# Altium schematic sheet 16gpio_misc.SchDoc
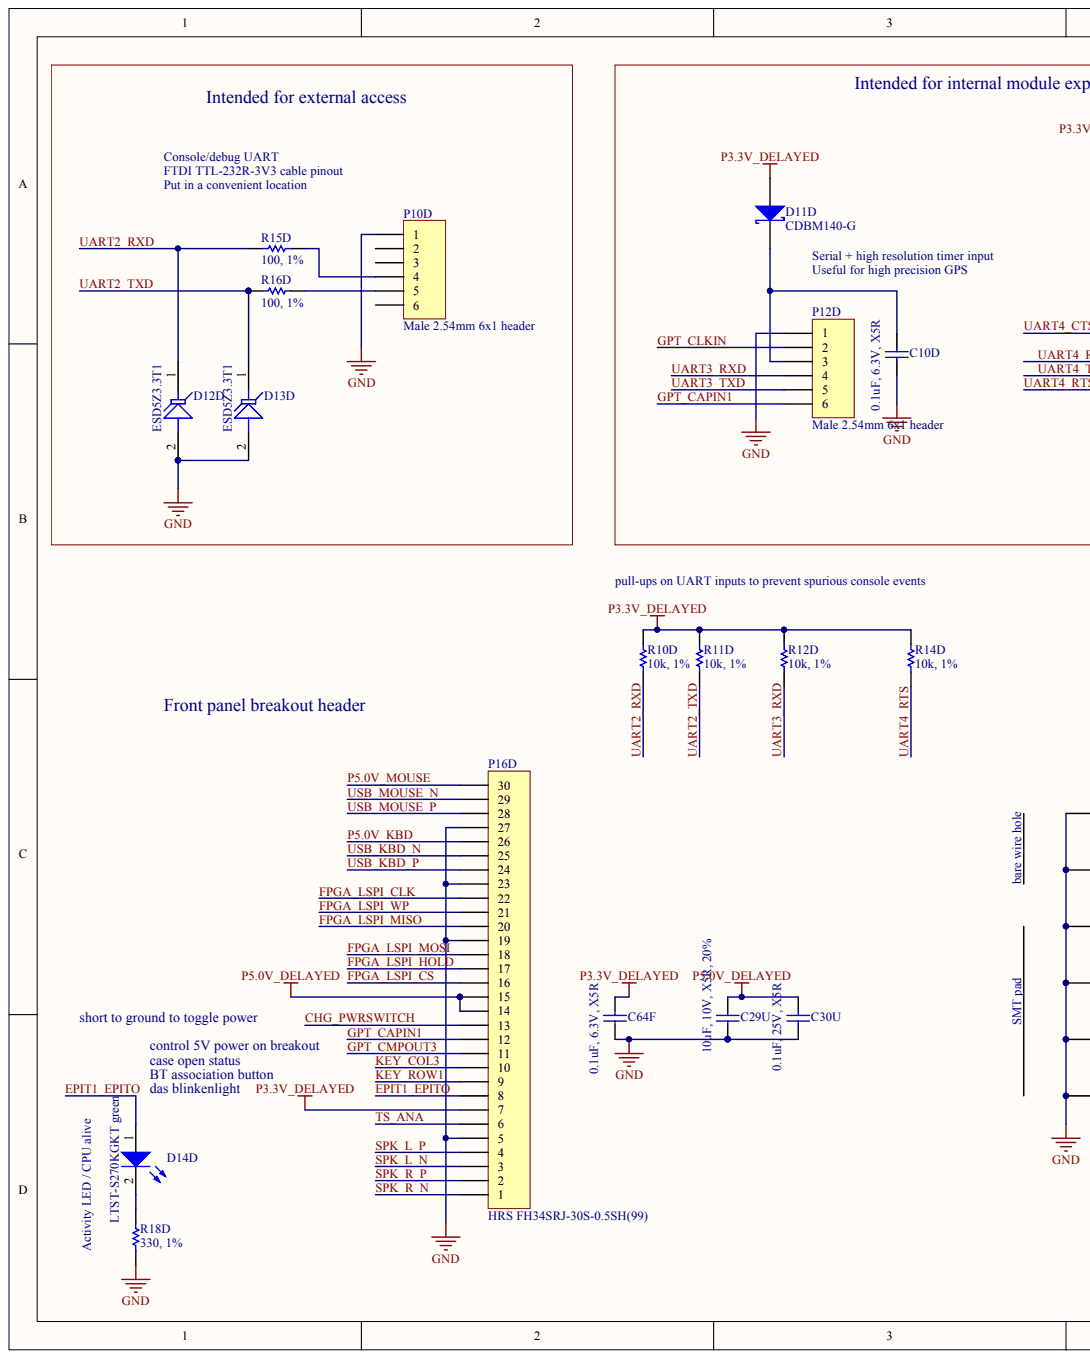
[diagram p0: 16gpio_misc.SchDoc, left]
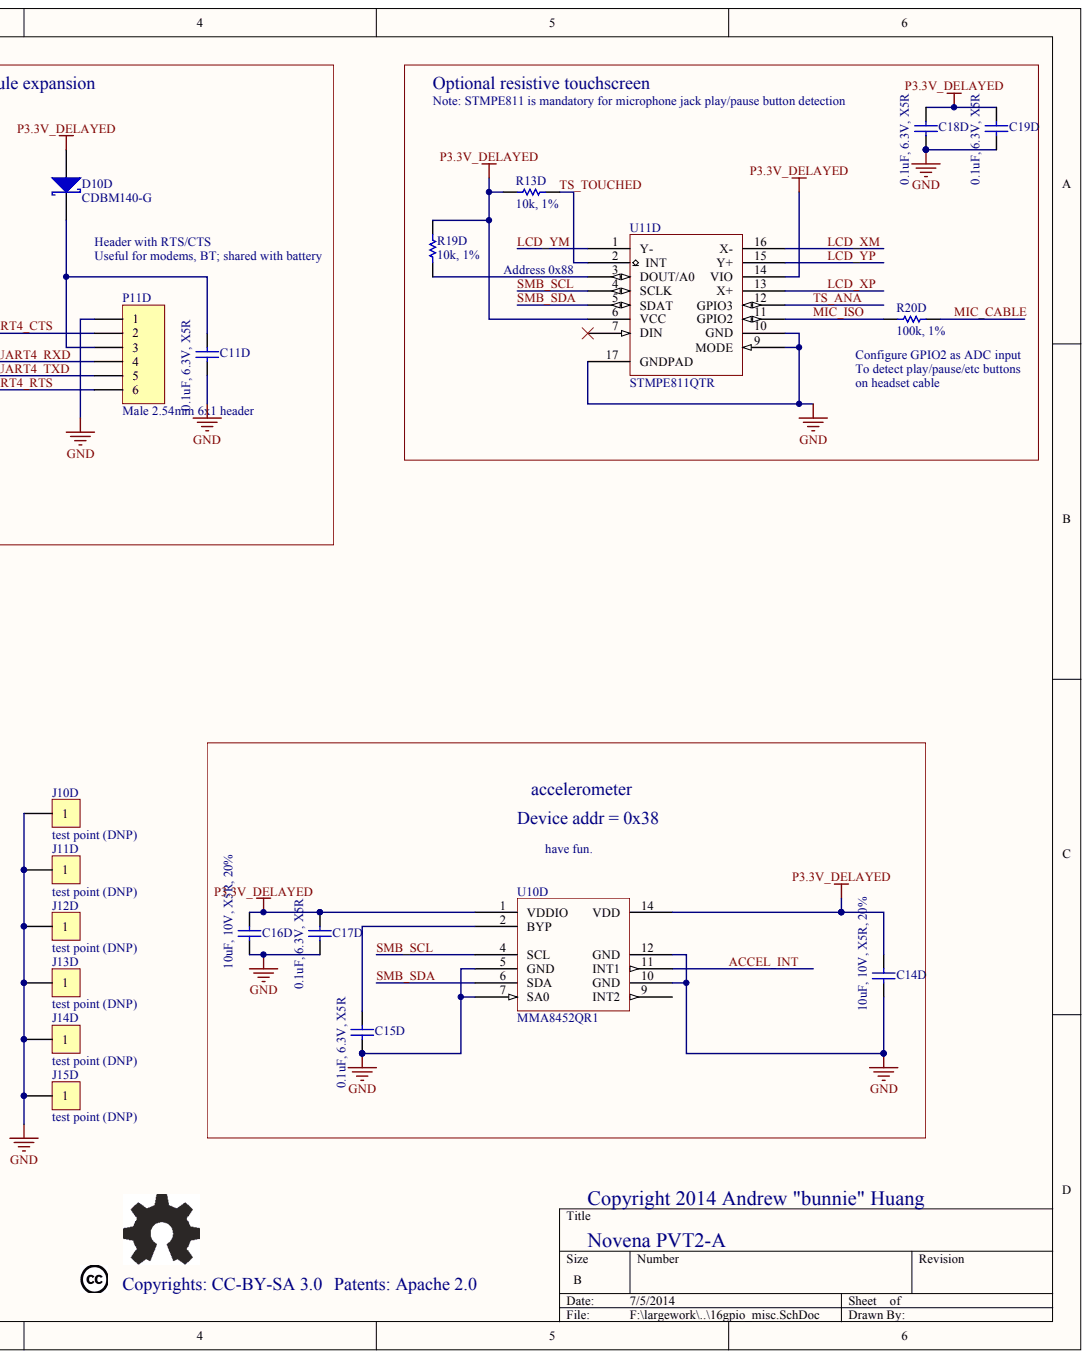
[diagram p1: 16gpio_misc.SchDoc, right]

## Parts
C10D  0.1uF, 6.3V, X5R
C11D  0.1uF, 6.3V, X5R
C14D  10uF, 10V, X5R, 20%
C15D  0.1uF, 6.3V, X5R
C16D  10uF, 10V, X5R, 20%
C17D  0.1uF, 6.3V, X5R
C18D  0.1uF, 6.3V, X5R
C19D  0.1uF, 6.3V, X5R
C29U  10uF, 10V, X5R, 20%
C30U  0.1uF, 25V, X5R
C64F  0.1uF, 6.3V, X5R
D10D  CDBM140-G
D11D  CDBM140-G
D12D  ESD5Z3.3T1
D13D  ESD5Z3.3T1
D14D  LTST-S270KGKT green
J10D  test point (DNP)
J11D  test point (DNP)
J12D  test point (DNP)
J13D  test point (DNP)
J14D  test point (DNP)
J15D  test point (DNP)
P10D  Male 2.54mm 6x1 header
P11D  Male 2.54mm 6x1 header
P12D  Male 2.54mm 6x1 header
P16D  HRS FH34SRJ-30S-0.5SH(99)
R10D  10k, 1%
R11D  10k, 1%
R12D  10k, 1%
R13D  10k, 1%
R14D  10k, 1%
R15D  100, 1%
R16D  100, 1%
R18D  330, 1%
R19D  10k, 1%
R20D  100k, 1%
U10D  MMA8452QR1
U11D  STMPE811QTR

## Nets
GND: C10D.2 C11D.2 C14D.2 C15D.2 C16D.2 C17D.2 C18D.2 C19D.2 C29U.2 C30U.2 C64F.2 D12D.2 D13D.2 J10D.1 J11D.1 J12D.1 J13D.1 J14D.1 J15D.1 P10D.1 P11D.1 P12D.1 P16D.5 P16D.19 P16D.23 P16D.27 R18D.1 U10D.5(GND) U10D.7(SA0) U10D.10(GND) U10D.12(GND) U11D.9(MODE) U11D.10(GND) U11D.17(GNDPAD)
P3.3V_DELAYED: C14D.1 C16D.1 C17D.1 C18D.1 C19D.1 C64F.1 D10D.1 D11D.1 P16D.7 R10D.1 R11D.1 R12D.1 R13D.2 R14D.1 R19D.1 U10D.1(VDDIO) U10D.14(VDD) U11D.6(VCC) U11D.14(VIO)
P5.0V_DELAYED: C29U.1 C30U.1 P16D.14 P16D.15
ACCEL_INT: U10D.11(INT1)
CHG_PWRSWITCH: P16D.13
EPIT1_EPITO: D14D.1 P16D.8
FPGA_LSPI_CLK: P16D.22
FPGA_LSPI_CS: P16D.16
FPGA_LSPI_HOLD: P16D.17
FPGA_LSPI_MISO: P16D.20
FPGA_LSPI_MOSI: P16D.18
FPGA_LSPI_WP: P16D.21
GPT_CAPIN1: P12D.6 P16D.12
GPT_CLKIN: P12D.2
GPT_CMPOUT3: P16D.11
KEY_COL3: P16D.10
KEY_ROW1: P16D.9
LCD_XM: U11D.16(X-)
LCD_XP: U11D.13(X+)
LCD_YM: U11D.1(Y-)
LCD_YP: U11D.15(Y+)
MIC_CABLE: R20D.2
MIC_ISO: R20D.1 U11D.11(GPIO2)
P5.0V_KBD: P16D.26
P5.0V_MOUSE: P16D.30
SMB_SCL: U10D.4(SCL) U11D.4(SCLK)
SMB_SDA: U10D.6(SDA) U11D.5(SDAT)
SPK_L_N: P16D.3
SPK_L_P: P16D.4
SPK_R_N: P16D.1
SPK_R_P: P16D.2
TS_ANA: P16D.6 U11D.12(GPIO3)
TS_TOUCHED: R13D.1 U11D.2(INT)
UART2_RXD: D12D.1 R10D.2 R15D.2
UART2_TXD: D13D.1 R11D.2 R16D.2
UART3_RXD: P12D.4 R12D.2
UART3_TXD: P12D.5
UART4_CTS: P11D.2
UART4_RTS: P11D.6 R14D.2
UART4_RXD: P11D.4
UART4_TXD: P11D.5
USB_KBD_N: P16D.25
USB_KBD_P: P16D.24
USB_MOUSE_N: P16D.29
USB_MOUSE_P: P16D.28
NetC10D_1: C10D.1 D11D.2 P12D.3
NetC11D_1: C11D.1 D10D.2 P11D.3
NetC15D_1: C15D.1 U10D.2(BYP)
NetD14D_2: D14D.2 R18D.2
NetP10D_4: P10D.4 R15D.1
NetP10D_5: P10D.5 R16D.1
NetR19D_2: R19D.2 U11D.3(DOUT/A0)

Not connected: P10D 2 3 6; U10D 9(INT2); U11D 7(DIN)

## Library data of the parts
D10D D11D = (no symbol name): Code - JEDEC: DO-214-AA; Published: 8-Jun-2000; Revision: July-2002: Re-released for DXP Platform.; Publisher: Altium Limited
R10D R11D R12D R13D R14D R15D R16D R18D R19D = (no symbol name): Value: 100k; Published: 8-Jun-2000; LatestRevisionDate: 17-Jul-2002; LatestRevisionNote: Re-released for DXP Platform.; PackageReference: AXIAL-0.3; Publisher: Altium Limited
C10D C11D C14D C15D C16D C17D C18D C19D C29U C30U C64F D12D D13D D14D J10D J11D J12D J13D J14D J15D P10D P11D P12D P16D R20D U10D U11D = (no symbol name)
  C10D C11D C14D C15D C16D C17D C18D C19D C29U C30U C64F: Published: 8-Jun-2000; LatestRevisionDate: 17-Jul-2002; LatestRevisionNote: Re-released for DXP Platform.; PackageReference: C1206
  D12D D13D: Published: 24-Mar-1999; LatestRevisionDate: 17-Jul-2002; LatestRevisionNote: Re-released for DXP Platform.; PackageReference: DO-7
  D14D: Published: 8-Jun-2000; LatestRevisionDate: 17-Jul-2002; LatestRevisionNote: Re-released for DXP Platform.; PackageVersion: Feb-2002; PackageReference: 3.2X1.6X1.1
  P10D P11D P12D P16D: LatestRevisionDate: 17-Jul-2002; LatestRevisionNote: Re-released for DXP Platform.
  R20D: Published: 8-Jun-2000; LatestRevisionDate: 17-Jul-2002; LatestRevisionNote: Re-released for DXP Platform.; PackageReference: AXIAL-0.3; Value: 100k
  C10D C11D C14D C15D C16D C17D C18D C19D C29U C30U C64F D12D D13D D14D P10D P11D P12D P16D R20D: Publisher: Altium Limited
